# Altium schematic sheet Connectors.SchDoc
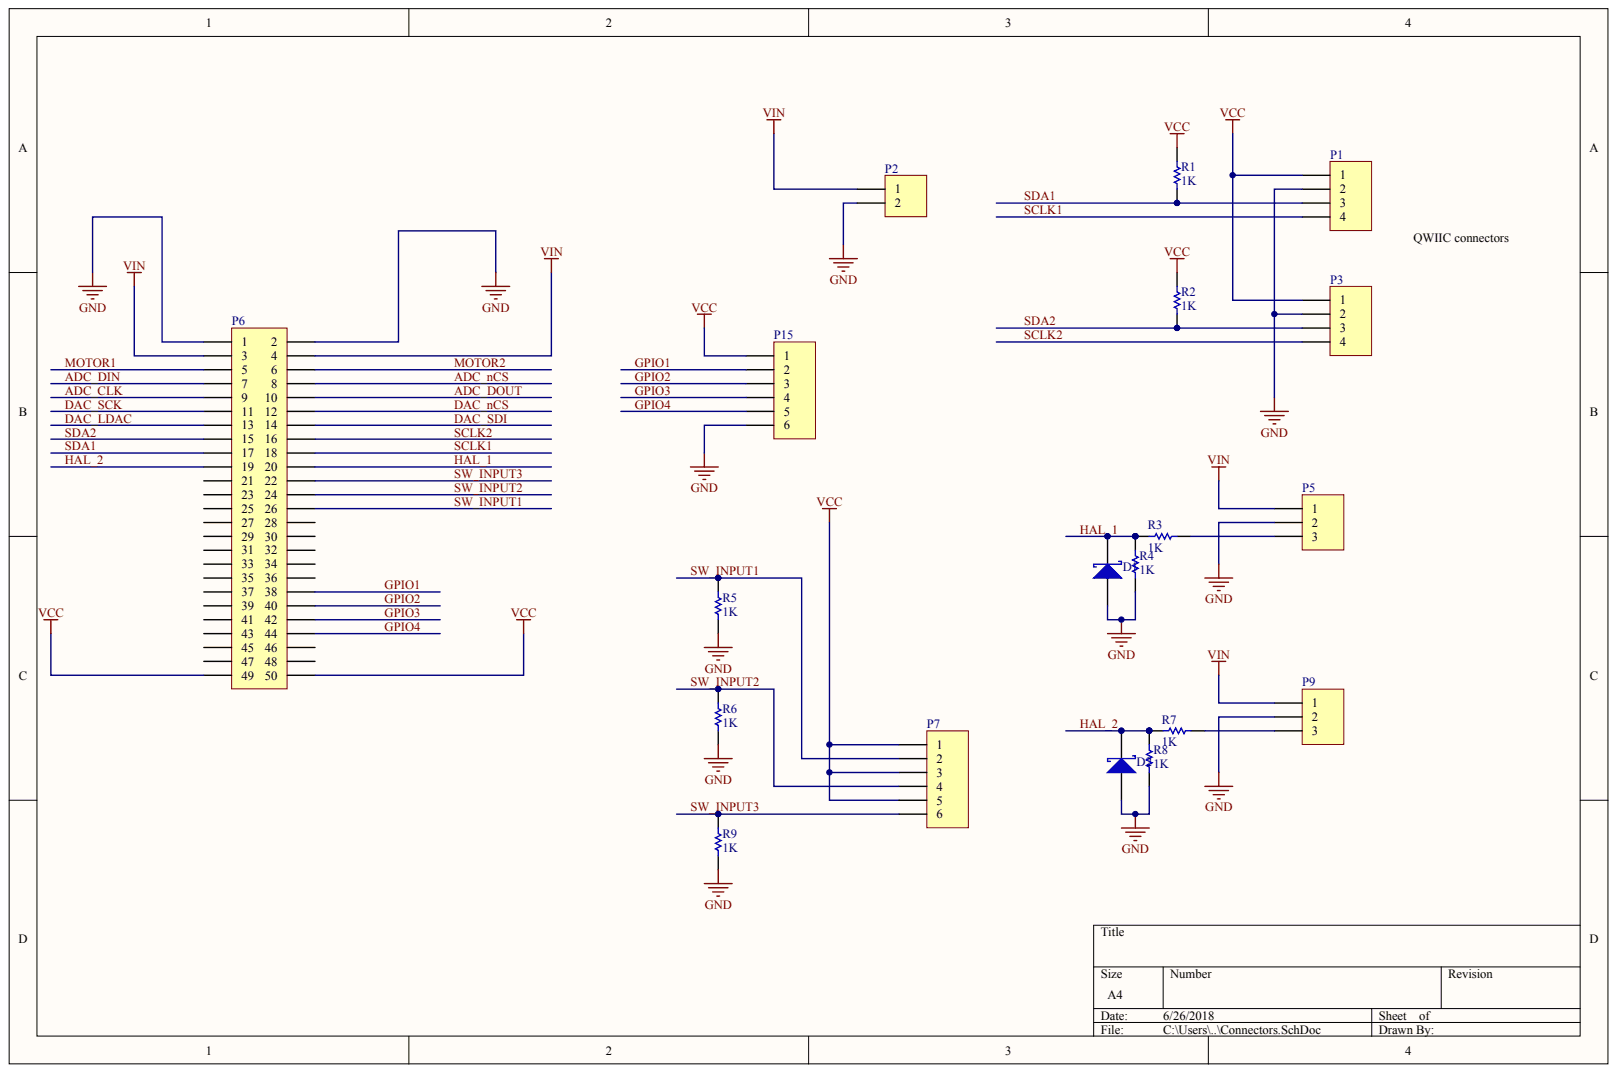
[diagram p0: Connectors.SchDoc]

## Parts
D1  Diode 11DQ03  (value not drawn)
D2  Diode 11DQ03  (value not drawn)
P1  Header 4  (value not drawn)
P2  Header 2  (value not drawn)
P3  Header 4  (value not drawn)
P5  Header 3  (value not drawn)
P6  Header 25X2  (value not drawn)
P7  Header 6  (value not drawn)
P9  Header 3  (value not drawn)
P15  Header 6  (value not drawn)
R1  value 1K  (hidden comment: Res1)
R2  value 1K  (hidden comment: Res1)
R3  value 1K  (hidden comment: Res1)
R4  value 1K  (hidden comment: Res1)
R5  value 1K  (hidden comment: Res1)
R6  value 1K  (hidden comment: Res1)
R7  value 1K  (hidden comment: Res1)
R8  value 1K  (hidden comment: Res1)
R9  value 1K  (hidden comment: Res1)

## Nets
GND: D1.1 D2.1 P1.2 P2.2 P3.2 P5.2 P6.1 P6.2 P9.2 P15.6 R4.2 R5.1 R6.1 R8.1 R9.1
VCC: P1.1 P3.1 P6.49 P6.50 P7.1 P7.3 P7.5 P15.1 R1.2 R2.2
VIN: P2.1 P5.1 P6.3 P6.4 P9.1
ADC_CLK: P6.9
ADC_DIN: P6.7
ADC_DOUT: P6.10
ADC_NCS: P6.8
DAC_LDAC: P6.13
DAC_NCS: P6.12
DAC_SCK: P6.11
DAC_SDI: P6.14
GPIO1: P6.38 P15.2
GPIO2: P6.40 P15.3
GPIO3: P6.42 P15.4
GPIO4: P6.44 P15.5
HAL_1: D1.2 P6.20 R3.2 R4.1
HAL_2: D2.2 P6.19 R7.1 R8.2
MOTOR1: P6.5
MOTOR2: P6.6
SCLK1: P1.4 P6.18
SCLK2: P3.4 P6.16
SDA1: P1.3 P6.17 R1.1
SDA2: P3.3 P6.15 R2.1
SW_INPUT1: P6.26 P7.2 R5.2
SW_INPUT2: P6.24 P7.4 R6.2
SW_INPUT3: P6.22 P7.6 R9.2
NetP5_3: P5.3 R3.1
NetP9_3: P9.3 R7.2

Not connected: P6 21 23 25 27 28 29 30 31 32 33 34 35 36 37 39 41 43 45 46 47 48

## Library data of the parts
D1 D2 P1-P3 P5-P7 P9 P15 R1-R9 = (no symbol name): LatestRevisionDate: 17-Jul-2002; LatestRevisionNote: Re-released for DXP Platform.; Publisher: Altium Limited
  D1 D2: Published: 8-Jun-2000; DatasheetVersion: Dec-1997; PackageReference: DO-204AL; PackageDescription: Diode, Axial; Body 5.2 x 2.7 mm (LxDia. max), Lead Dia. 0.86 mm (max); Code_JEDEC: DO-204-AL; ComponentLink1Description: Manufacturer Link; ComponentLink1URL: http://www.irf.com/; ComponentLink2Description: Datasheet; ComponentLink2URL: http://www.irf.com/product-info/datasheets/data/11dq03.pdf; Digikey PN: 1N5226BFSCT-ND
  P1 P3: Digikey PN: 455-1804-1-ND
  R1-R9: Published: 8-Jun-2000; PackageReference: AXIAL-0.3; PackageDescription: Axial Device, Thru-Hole; 2 Leads; 0.3 in Pin Spacing
